# Altium schematic sheet control_module.SchDoc
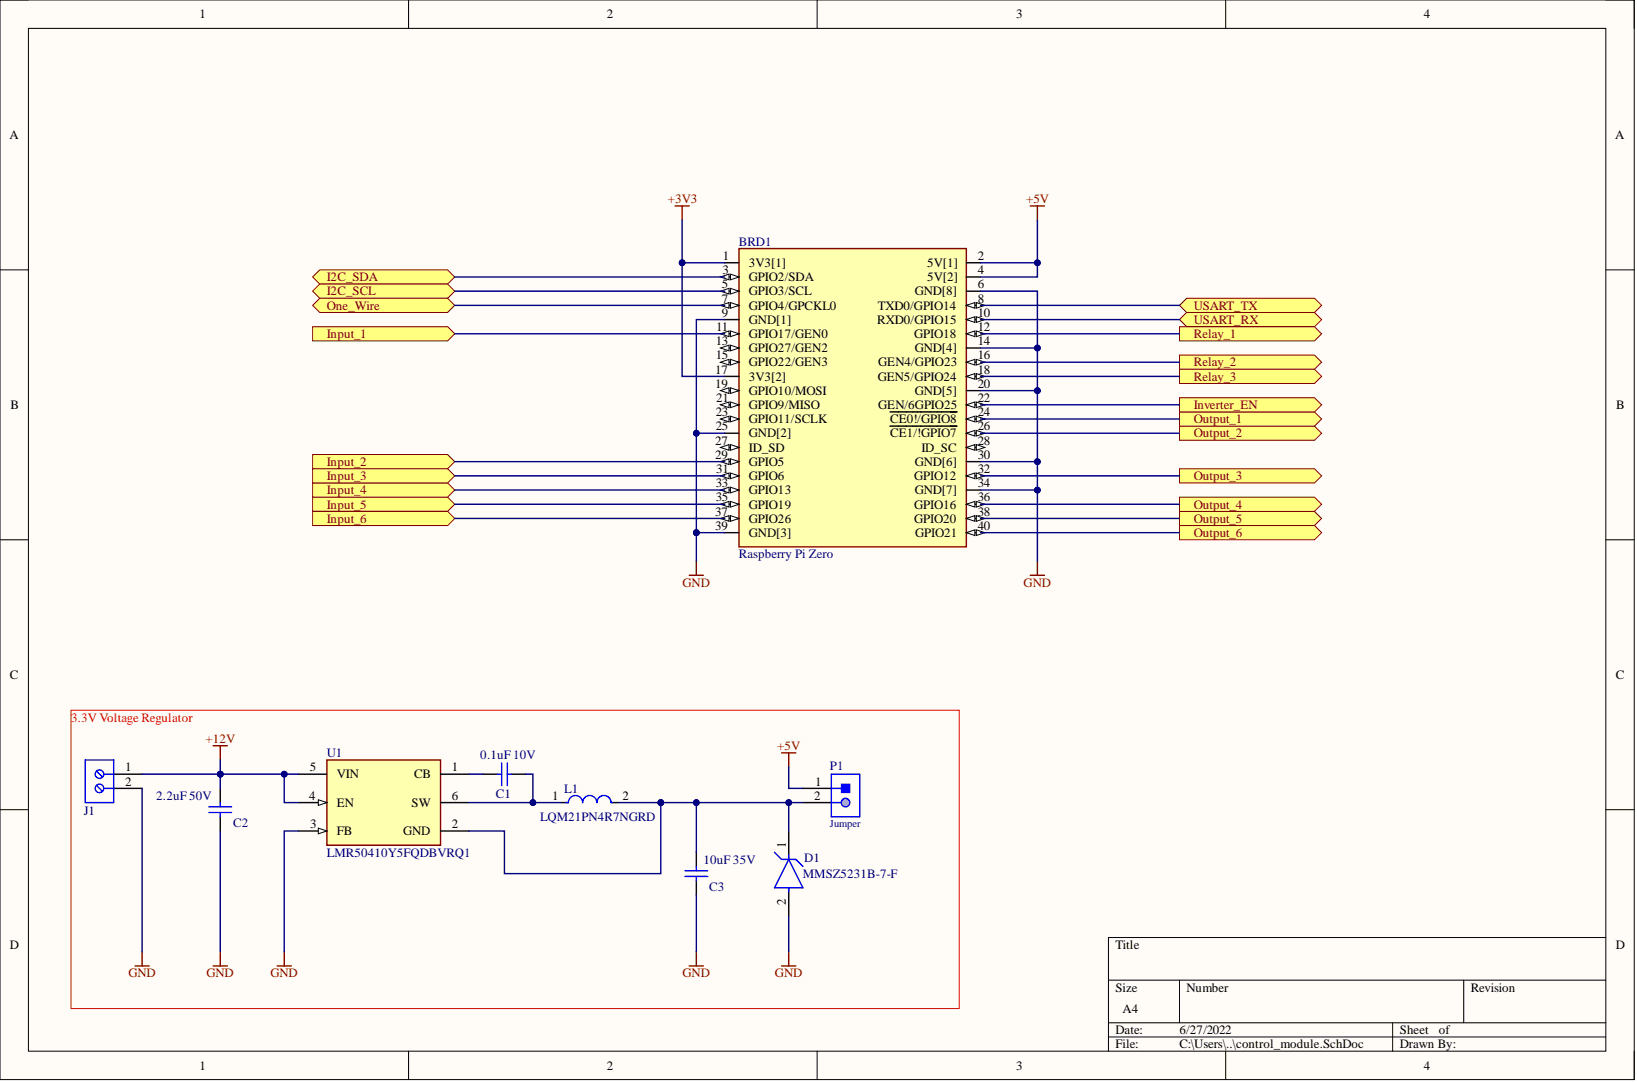
[diagram p0: control_module.SchDoc]

## Parts
BRD1  Raspberry Pi Zero  MF: Raspberry Pi  [RASPBERRY_PI_ZERO]
C1  0.1uF 10V  [CAPC2013X88X50ML20T25]
C2  2.2uF 50V  [CAPC2013X88X50ML20T25]
C3  10uF 35V  [CAPC2013X88X50ML20T25]
D1  MMSZ5231B-7-F  [FP-SOD123-MFG]
J1  282836-2  [TECO-282836-2_V]  (value not drawn)
L1  LQM21PN4R7NGRD  [FP-LQM21PH_G0-IPC_B]
P1  Jumper  [61300211121]
U1  LMR50410Y5FQDBVRQ1  [FP-DBV0006A-IPC_C]

## Ports
I2C_SCL, I2C_SDA, Input_1, Input_2, Input_3, Input_4, Input_5, Input_6, Inverter_EN, One_Wire, Output_1, Output_2, Output_3, Output_4, Output_5, Output_6, Relay_1, Relay_2, Relay_3, USART_RX, USART_TX

## Nets
+3V3: BRD1.1(3V3[1]) BRD1.17(3V3[2])
+5V: BRD1.2(5V[1]) BRD1.4(5V[2]) P1.1
+12V: C2.2 J1.1 U1.4(EN) U1.5(VIN)
GND: BRD1.6(GND[8]) BRD1.9(GND[1]) BRD1.14(GND[4]) BRD1.20(GND[5]) BRD1.25(GND[2]) BRD1.30(GND[6]) BRD1.34(GND[7]) BRD1.39(GND[3]) C2.1 C3.2 D1.2 J1.2 U1.3(FB)
I2C_SCL: BRD1.5(GPIO3/SCL) ; port I2C_SCL
I2C_SDA: BRD1.3(GPIO2/SDA) ; port I2C_SDA
Input_1: BRD1.11(GPIO17/GEN0) ; port Input_1
Input_2: BRD1.29(GPIO5) ; port Input_2
Input_3: BRD1.31(GPIO6) ; port Input_3
Input_4: BRD1.33(GPIO13) ; port Input_4
Input_5: BRD1.35(GPIO19) ; port Input_5
Input_6: BRD1.37(GPIO26) ; port Input_6
Inverter_EN: BRD1.22(GEN/6GPIO25) ; port Inverter_EN
One_Wire: BRD1.7(GPIO4/GPCKL0) ; port One_Wire
Output_1: BRD1.24(CE0!/GPIO8) ; port Output_1
Output_2: BRD1.26(CE1/!GPIO7) ; port Output_2
Output_3: BRD1.32(GPIO12) ; port Output_3
Output_4: BRD1.36(GPIO16) ; port Output_4
Output_5: BRD1.38(GPIO20) ; port Output_5
Output_6: BRD1.40(GPIO21) ; port Output_6
Relay_1: BRD1.12(GPIO18) ; port Relay_1
Relay_2: BRD1.16(GEN4/GPIO23) ; port Relay_2
Relay_3: BRD1.18(GEN5/GPIO24) ; port Relay_3
USART_RX: BRD1.10(RXD0/GPIO15) ; port USART_RX
USART_TX: BRD1.8(TXD0/GPIO14) ; port USART_TX
NetC1_1: C1.1 L1.1 U1.6(SW)
NetC1_2: C1.2 U1.1(CB)
NetC3_1: C3.1 D1.1 L1.2 P1.2 U1.2(GND)

Not connected: BRD1 13(GPIO27/GEN2) 15(GPIO22/GEN3) 19(GPIO10/MOSI) 21(GPIO9/MISO) 23(GPIO11/SCLK) 27(ID_SD) 28(ID_SC)

## Library data of the parts
BRD1 = MCU_raspberryPi_zero: Raspberry Pi Zero with Header; MP: ADA3708; Availability: Unavailable; Component Kind: Standard; Library Name: integrated_library.IntLib; Package: None; Price: None; SnapEDA_Link: https://www.snapeda.com/parts/ADA3708/Raspberry%20Pi/view-part/2770118/?ref=snap
C1-C3 = Cap_0805: Capacitor; Component Kind: Standard; Library Name: Schlib.SchLib
D1 = CMP-12792-000005-1: DIODE ZENER 5.1V 500MW SOD123; Manufacturer: Diodes; Max Junction Temp: +150°C; Max Reverse Leakage Current: 5uA; Max Zener Voltage: 5.36V; Power Dissipation: 370mW; Standoff Height: 0mm; Zener Impedance: 17Ω; Automotive: No; Category: Diode; Component Kind: Standard; ComponentLink2Description: Datasheet; ComponentLink2URL: https://www.diodes.com/assets/Datasheets/ds18010.pdf; Device Class L1: Discrete Semiconductors; Device Class L2: Diodes; Device Class L3: Zener Diodes; Digikey Description: DIODE ZENER 5.1V 500MW SOD123; Footprint Url: https://www.diodes.com/assets/Package-Files/SOD123.pdf; Height: 1.45mm; Lead Free: Yes; Library Name: Altium Content Vault; Manufacturer Part Number: MMSZ5231B-7-F; Mouser Description: Zener Diodes 5.1V 500mW; Nom Zener Voltage: 5.1V; Octopart: https://octopart.com/mmsz5231b-7-f-diodes+inc.-55400050; Package: SOD123; RoHS: TRUE; Temperature: -65°C to +150°C; Temperature Range High: +150°C; Temperature Range Low: -65°C
J1 = CMP-1684-00017-1: Female Header, Pitch 5 mm, 1 x 2 Position, Height 10 mm, Tail Length 3.5 mm, Rohs, Bulk; Manufacturer: TE Connectivity; 3D Model: 282836-2.pdf; Clamp Material - Plating: Brass-NickelPlated; Current: 13.5A; Dynamic Catalog: BuchananSeries; Featured Product: EurostyleTerminalBlockConnectors; Mating Orientation: HorizontalwithBoard; Number of Levels: 1; Other Names: 5ULV-02B  A98076; Pitch: 0.197"(5.00mm); Positions Per Level: 2; Product Training Modules: Wire-to-BoardEurostyleTerminalBlocks; Screw Thread: M3; Torque - Screw: 0.68Nm(6Lb-In); Voltage: 300V; Wire Gauge: 16-30AWG; Wire Termination: Screw-RisingCageClamp; Category: Connectors,Interconnects; Color: Green; Component Kind: Standard; Contact Material - Plating: Brass-TinPlated; Datasheet URL: http://www.te.com.cn/commerce/DocumentDelivery/DDEController?Action=showdoc&DocId=Catalog+Section%7F1308389_EUROSTYLE_TERMINAL_BLOCKS%7F0607%7Fpdf%7FEnglish%7FENG_CS_1308389_EUROSTYLE_TERMINAL_BLOCKS_0607.pdf%7F282836-2; Family: TerminalBlocks-WiretoBoard; Features: Interlocking(Side); Housing Material: Polyamide(PA66),Nylon6/6; Library Name: Altium Content Vault; Manufacturer URL: http://www.te.com; Mounting Type: ThroughHole; Package Description: Female Header, Pitch 5 mm, 1 x 2 Position, Height 10 mm, Tail Length 3.5 mm; Package Reference: THD; Packaging: Bulk; RoHS Information: 282836-2StatementofCompliance; Screw Material - Plating: Brass-NickelPlated; Series: Buchanan; Standard Package: 300
L1 = CMP-06042-016903-1: Multilayer Type Inductor for Power Lines, 0805 (2012), 4.7μH, 30%, 0.288Ω, 0.8A; Core Material: Ferrite; Current Rating: 800mA; DC Resistance (DCR): 288mR; Inductance: 4.7uH; Self Resonant Frequency: 30MHz; Shielding: Shielded; Test Frequency: 1MHz; Case Code (Imperial): 0805; Case Code (Metric): 2012; Case/Package: 0805; Component Kind: Standard; DC Current: 800mA; Depth: 1.4mm; Height: 0.9mm; Height - Seated (Max): 0.039inch; Lead Free: Lead Free; Length: 0.079inch; Library Name: Altium Content Vault; Max DC Current: 800mA; Max Operating Temperature: 125°C; Military Standard: Not; Min Operating Temperature: -55°C; Mount: Surface Mount; Package Quantity: 4000; Packaging: Tape and Reel; Radiation Hardening: No; RoHS Compliant: Yes; Series: LQM21PN_GR; Termination: SMD/SMT; Tolerance: 30%; Width: 1.25mm
P1 = CMP-1712-00002-4: THT Vertical Pin Header WR-PHD, Pitch 2.54 mm, Single Row, 2 pins; Manufacturer: Wurth Elektronik; Contact Resistance: 20mOhm; Gender: Male; Pin Number: 2; Pitch: 2.54mm; Rated Current: 3A; Withstanding Voltage: 500VAC; Working Voltage: 250VAC; Component Kind: Standard; Datasheet URL: https://katalog.we-online.de/em/datasheet/6130xx11121.pdf; Library Name: Altium Content Vault; Manufacturer URL: http://www.we-online.de; Mounting Technology: THT; Operating Temperature: -40to105degC; Orientation: Vertical; Package Reference: 61300211121; Packaging: Bag; Part Number: 61300211121; Row Number: Single; Publisher: WurthElektronik
U1 = CMP-04918-000667-1: AUTOMOTIVE QUALIFIED SIMPLE SWIT; Component Kind: Standard; Library Name: Altium Content Vault
